# Altium schematic sheet BBB Headers.SchDoc
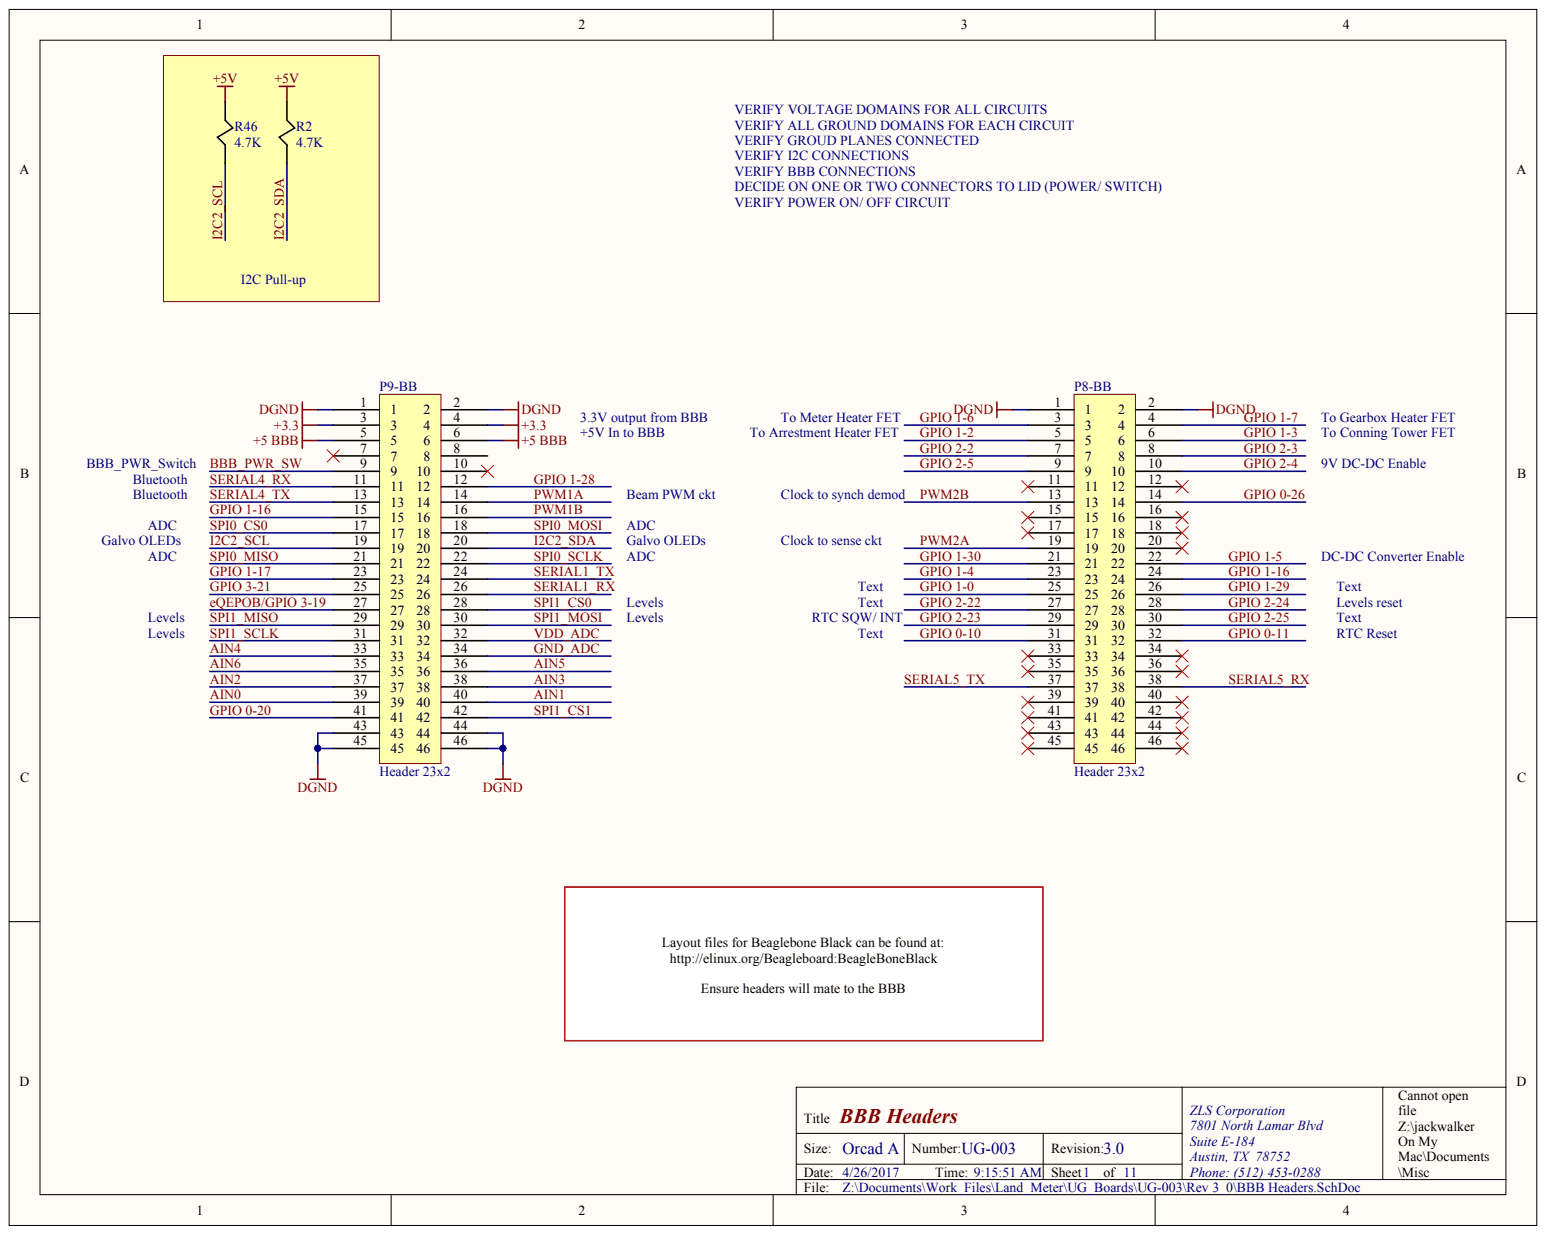
[diagram p0: BBB Headers.SchDoc]

## Parts
P8-BB  Header 23x2  Connector Type: Header
P9-BB  Header 23x2  Connector Type: Header
R2  4.7K
R46  4.7K

## Nets
+3.3: P9-BB.3 P9-BB.4
+5 BBB: P9-BB.5 P9-BB.6
+5V: R2.2 R46.2
DGND: P8-BB.1 P8-BB.2 P9-BB.1 P9-BB.2 P9-BB.43 P9-BB.44 P9-BB.45 P9-BB.46
AIN0: P9-BB.39
AIN1: P9-BB.40
AIN2: P9-BB.37
AIN3: P9-BB.38
AIN4: P9-BB.33
AIN5: P9-BB.36
AIN6: P9-BB.35
BBB_PWR_SW: P9-BB.9
EQEPOB/GPIO 3-19: P9-BB.27
GND_ADC: P9-BB.34
GPIO 0-10: P8-BB.31
GPIO 0-11: P8-BB.32
GPIO 0-20: P9-BB.41
GPIO 0-26: P8-BB.14
GPIO 1-0: P8-BB.25
GPIO 1-2: P8-BB.5
GPIO 1-3: P8-BB.6
GPIO 1-4: P8-BB.23
GPIO 1-5: P8-BB.22
GPIO 1-6: P8-BB.3
GPIO 1-7: P8-BB.4
GPIO 1-16: P8-BB.24 P9-BB.15
GPIO 1-17: P9-BB.23
GPIO 1-28: P9-BB.12
GPIO 1-29: P8-BB.26
GPIO 1-30: P8-BB.21
GPIO 2-2: P8-BB.7
GPIO 2-3: P8-BB.8
GPIO 2-4: P8-BB.10
GPIO 2-5: P8-BB.9
GPIO 2-22: P8-BB.27
GPIO 2-23: P8-BB.29
GPIO 2-24: P8-BB.28
GPIO 2-25: P8-BB.30
GPIO 3-21: P9-BB.25
I2C2_SCL: P9-BB.19 R46.1
I2C2_SDA: P9-BB.20 R2.1
PWM1A: P9-BB.14
PWM1B: P9-BB.16
PWM2A: P8-BB.19
PWM2B: P8-BB.13
SERIAL1_RX: P9-BB.26
SERIAL1_TX: P9-BB.24
SERIAL4_RX: P9-BB.11
SERIAL4_TX: P9-BB.13
SERIAL5_RX: P8-BB.38
SERIAL5_TX: P8-BB.37
SPI0_CS0: P9-BB.17
SPI0_MISO: P9-BB.21
SPI0_MOSI: P9-BB.18
SPI0_SCLK: P9-BB.22
SPI1_CS0: P9-BB.28
SPI1_CS1: P9-BB.42
SPI1_MISO: P9-BB.29
SPI1_MOSI: P9-BB.30
SPI1_SCLK: P9-BB.31
VDD_ADC: P9-BB.32

Not connected: P8-BB 11 12 15 16 17 18 20 33 34 35 36 39 40 41 42 43 44 45 46; P9-BB 7 8 10

## Library data of the parts
P8-BB P9-BB = (no symbol name): Manufacturer 1: Amphenol FCI; Manufacturer Part Number 1: 861400062YO2LF; Description 1: HEADER BERGSTIK; Category 1: Connectors, Interconnects; Pitch - Mating: 0.100" (2.54mm); Style: Board to Board or Cable; Shrouding: Unshrouded; Number of Positions: 6; Number of Positions Loaded: All; Contact Length - Mating: 0.276" (7.00mm); Contact Length - Post: 0.118" (3.00mm); Overall Contact Length: 0.496" (12.60mm); Material Flammability Rating: UL94 V-0; Current Rating: 3A; Voltage Rating: 1000V; Supplier 1: Digi-Key; Supplier Part Number 1: 861400062YO2LF-ND; RoHS 1: RoHS Compliant; Stock 1: 0; Pricing 1: 6000=0.09952 (USD); Packaging: Bulk; Part Status: Active; Contact Type: Male Pin; Number of Rows: 2; Row Spacing - Mating: 0.100" (2.54mm); Mounting Type: Through Hole; Termination: Solder; Fastening Type: -; Insulation Height: 0.102" (2.60mm); Contact Shape: Square; Contact Finish - Mating: Gold or Gold, GXT™; Contact Finish Thickness - Mating: 8µin (0.20µm); Contact Finish - Post: -; Contact Material: Copper Alloy; Insulation Material: Thermoplastic; Features: -; Operating Temperature: -55°C ~ 125°C; Ingress Protection: -; Insulation Color: -; Mated Stacking Heights: -; Contact Finish Thickness - Post: -; Applications: -; ComponentLink1URL: http://portal.fciconnect.com/Comergent//fci/drawing/c-8614-0086.pdf; ComponentLink1Description: http://portal.fciconnect.com/Comergent//fci/drawing/c-8614-0086.pdf
R2 R46 = (no symbol name): Manufacturer 1: Vishay Dale; Manufacturer Part Number 1: CRCW08054K70FKEA; Description 1: RES SMD 4.7K OHM 1% 1/8W 0805; Category 1: Resistors; Tolerance: ±1%; Power (Watts): 0.125W, 1/8W; Temperature Coefficient: ±100ppm/°C; Supplier 1: Digi-Key; Supplier Part Number 1: 541-4.70KCCT-ND; RoHS 1: RoHS Compliant; Stock 1: 49963; Pricing 1: 1=0.1, 10=0.042, 25=0.0304, 50=0.0232, 100=0.017, 250=0.013, 500=0.0104, 1000=0.00765 (USD); Packaging: Cut Tape (CT); Part Status: Active; Resistance (Ohms): 4.7k; Composition: Thick Film; Features: Automotive AEC-Q200; Operating Temperature: -55°C ~ 155°C; Package / Case: 0805 (2012 Metric); Supplier Device Package: 0805; Size / Dimension: 0.079" L x 0.049" W (2.00mm x 1.25mm); Height - Seated (Max): 0.020" (0.50mm); Failure Rate: -; ComponentLink1URL: http://www.vishay.com/docs/20035/dcrcwe3.pdf; ComponentLink1Description: http://www.vishay.com/docs/20035/dcrcwe3.pdf
